# Altium schematic sheet FPGA-iCE-Cam.SchDoc
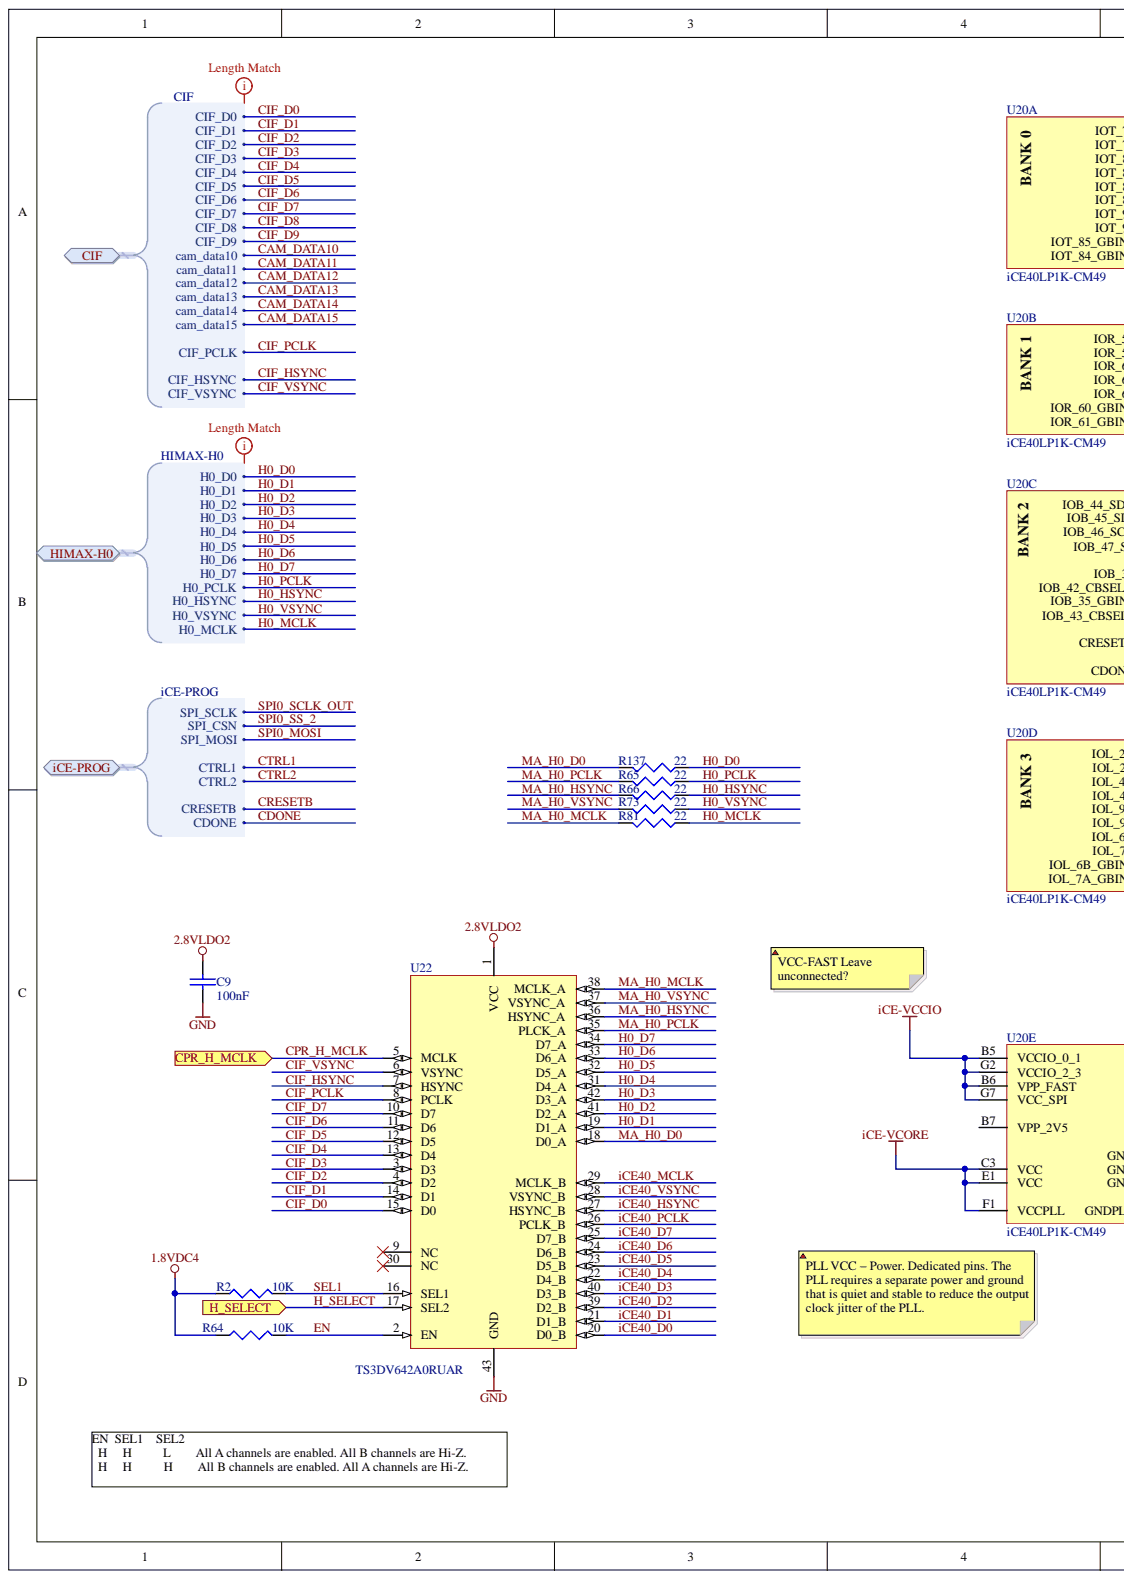
[diagram p0: FPGA-iCE-Cam.SchDoc, left]
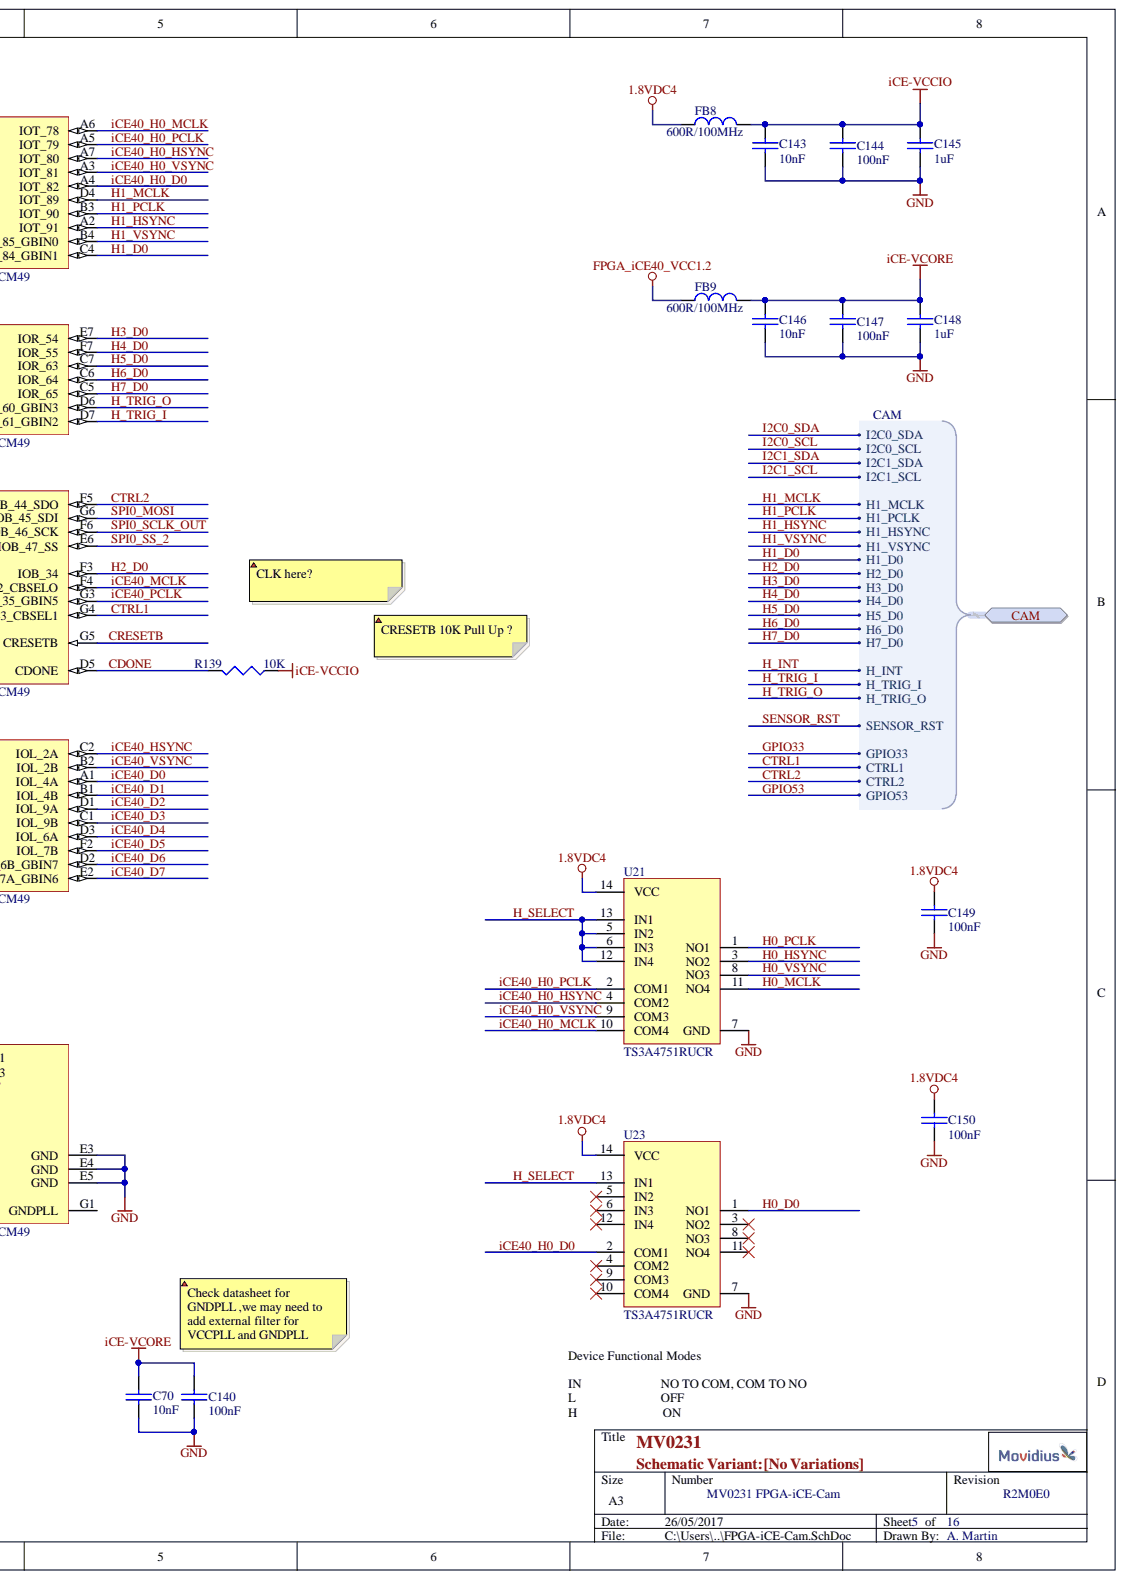
[diagram p1: FPGA-iCE-Cam.SchDoc, right]

## Parts
C9  100nF  [0402]
C70  10nF  [0201]
C140  100nF  [0402]
C143  10nF  [0201]
C144  100nF  [0402]
C145  1uF  [0402]
C146  10nF  [0201]
C147  100nF  [0402]
C148  1uF  [0402]
C149  100nF  [0402]
C150  100nF  [0402]
FB8  600R/100MHz  [0805]
FB9  600R/100MHz  [0805]
R2  10K  [0402]
R64  10K  [0402]
R65  22  [0402]
R66  22  [0402]
R73  22  [0402]
R81  22  [0402]
R137  22  [0402]
R139  10K  [0402]
U20  iCE40LP1K-CM49  [LATC-ucBGA-49]  (parts A,B,C,D,E)
U21  TS3A4751RUCR  [S-PX2QFN-14]
U22  TS3DV642A0RUAR  [PWQFN-N42]
U23  TS3A4751RUCR  [S-PX2QFN-14]

## Ports
CAM, CAM.CTRL1, CAM.CTRL2, CAM.GPIO33, CAM.GPIO53, CAM.H1_D0, CAM.H1_HSYNC, CAM.H1_MCLK, CAM.H1_PCLK, CAM.H1_VSYNC, CAM.H2_D0, CAM.H3_D0, CAM.H4_D0, CAM.H5_D0, CAM.H6_D0, CAM.H7_D0, CAM.H_INT, CAM.H_TRIG_I, CAM.H_TRIG_O, CAM.I2C0_SCL, CAM.I2C0_SDA, CAM.I2C1_SCL, CAM.I2C1_SDA, CAM.SENSOR_RST, CIF, CIF.cam_data10, CIF.cam_data11, CIF.cam_data12, CIF.cam_data13, CIF.cam_data14, CIF.cam_data15, CIF.CIF_D0, CIF.CIF_D1, CIF.CIF_D2, CIF.CIF_D3, CIF.CIF_D4, CIF.CIF_D5, CIF.CIF_D6, CIF.CIF_D7, CIF.CIF_D8, CIF.CIF_D9, CIF.CIF_HSYNC, CIF.CIF_PCLK, CIF.CIF_VSYNC, CPR_H_MCLK, H_SELECT, HIMAX-H0, HIMAX-H0.H0_D0, HIMAX-H0.H0_D1, HIMAX-H0.H0_D2, HIMAX-H0.H0_D3, HIMAX-H0.H0_D4, HIMAX-H0.H0_D5, HIMAX-H0.H0_D6, HIMAX-H0.H0_D7, HIMAX-H0.H0_HSYNC, HIMAX-H0.H0_MCLK, HIMAX-H0.H0_PCLK, HIMAX-H0.H0_VSYNC, iCE-PROG, iCE-PROG.CDONE, iCE-PROG.CRESETB, iCE-PROG.CTRL1, iCE-PROG.CTRL2, iCE-PROG.SPI_CSN, iCE-PROG.SPI_MOSI, iCE-PROG.SPI_SCLK

## Nets
1.8VDC4: C149.2 C150.2 FB8.2 R2.1 R64.1 U21.14(VCC) U23.14(VCC)
2.8VLDO2: C9.2 U22.1(VCC)
FPGA_iCE40_VCC1.2: FB9.2
GND: C9.1 C70.1 C140.1 C143.1 C144.1 C145.2 C146.1 C147.1 C148.2 C149.1 C150.1 U20.E3(GND) U20.E4(GND) U20.E5(GND) U21.7(GND) U22.43(GND) U23.7(GND)
iCE-VCCIO: C143.2 C144.2 C145.1 FB8.1 R139.1 U20.B5(VCCIO_0_1) U20.B6(VPP_FAST) U20.G2(VCCIO_2_3) U20.G7(VCC_SPI)
iCE-VCORE: C70.2 C140.2 C146.2 C147.2 C148.1 FB9.1 U20.C3(VCC) U20.E1(VCC) U20.F1(VCCPLL)
CDONE: R139.2 U20.D5(CDONE) ; port iCE-PROG
CIF_D0: U22.15(D0) ; port CIF
CIF_D1: U22.14(D1) ; port CIF
CIF_D2: U22.4(D2) ; port CIF
CIF_D3: U22.3(D3) ; port CIF
CIF_D4: U22.13(D4) ; port CIF
CIF_D5: U22.12(D5) ; port CIF
CIF_D6: U22.11(D6) ; port CIF
CIF_D7: U22.10(D7) ; port CIF
CIF_HSYNC: U22.7(HSYNC) ; port CIF
CIF_PCLK: U22.8(PCLK) ; port CIF
CIF_VSYNC: U22.6(VSYNC) ; port CIF
CPR_H_MCLK: U22.5(MCLK) ; port CPR_H_MCLK
CRESETB: U20.G5(CRESETB) ; port iCE-PROG
CTRL1: U20.G4(IOB_43_CBSEL1) ; port CAM, port iCE-PROG
CTRL2: U20.F5(IOB_44_SDO) ; port CAM, port iCE-PROG
EN: R64.2 U22.2(EN)
H0_D0: R137.1 U23.1(NO1) ; port HIMAX-H0
H0_D1: U22.19(D1_A) ; port HIMAX-H0
H0_D2: U22.41(D2_A) ; port HIMAX-H0
H0_D3: U22.42(D3_A) ; port HIMAX-H0
H0_D4: U22.31(D4_A) ; port HIMAX-H0
H0_D5: U22.32(D5_A) ; port HIMAX-H0
H0_D6: U22.33(D6_A) ; port HIMAX-H0
H0_D7: U22.34(D7_A) ; port HIMAX-H0
H0_HSYNC: R66.1 U21.3(NO2) ; port HIMAX-H0
H0_MCLK: R81.1 U21.11(NO4) ; port HIMAX-H0
H0_PCLK: R65.1 U21.1(NO1) ; port HIMAX-H0
H0_VSYNC: R73.1 U21.8(NO3) ; port HIMAX-H0
H1_D0: U20.C4(IOT_84_GBIN1) ; port CAM
H1_HSYNC: U20.A2(IOT_91) ; port CAM
H1_MCLK: U20.D4(IOT_89) ; port CAM
H1_PCLK: U20.B3(IOT_90) ; port CAM
H1_VSYNC: U20.B4(IOT_85_GBIN0) ; port CAM
H2_D0: U20.F3(IOB_34) ; port CAM
H3_D0: U20.E7(IOR_54) ; port CAM
H4_D0: U20.F7(IOR_55) ; port CAM
H5_D0: U20.C7(IOR_63) ; port CAM
H6_D0: U20.C6(IOR_64) ; port CAM
H7_D0: U20.C5(IOR_65) ; port CAM
H_SELECT: U21.5(IN2) U21.6(IN3) U21.12(IN4) U21.13(IN1) U22.17(SEL2) U23.13(IN1) ; port H_SELECT
H_TRIG_I: U20.D7(IOR_61_GBIN2) ; port CAM
H_TRIG_O: U20.D6(IOR_60_GBIN3) ; port CAM
iCE40_D0: U20.A1(IOL_4A) U22.20(D0_B)
iCE40_D1: U20.B1(IOL_4B) U22.21(D1_B)
iCE40_D2: U20.D1(IOL_9A) U22.39(D2_B)
iCE40_D3: U20.C1(IOL_9B) U22.40(D3_B)
iCE40_D4: U20.D3(IOL_6A) U22.22(D4_B)
iCE40_D5: U20.F2(IOL_7B) U22.23(D5_B)
iCE40_D6: U20.D2(IOL_6B_GBIN7) U22.24(D6_B)
iCE40_D7: U20.E2(IOL_7A_GBIN6) U22.25(D7_B)
iCE40_H0_D0: U20.A4(IOT_82) U23.2(COM1)
iCE40_H0_HSYNC: U20.A7(IOT_80) U21.4(COM2)
iCE40_H0_MCLK: U20.A6(IOT_78) U21.10(COM4)
iCE40_H0_PCLK: U20.A5(IOT_79) U21.2(COM1)
iCE40_H0_VSYNC: U20.A3(IOT_81) U21.9(COM3)
iCE40_HSYNC: U20.C2(IOL_2A) U22.27(HSYNC_B)
iCE40_MCLK: U20.F4(IOB_42_CBSELO) U22.29(MCLK_B)
iCE40_PCLK: U20.G3(IOB_35_GBIN5) U22.26(PCLK_B)
iCE40_VSYNC: U20.B2(IOL_2B) U22.28(VSYNC_B)
MA_H0_D0: R137.2 U22.18(D0_A)
MA_H0_HSYNC: R66.2 U22.36(HSYNC_A)
MA_H0_MCLK: R81.2 U22.38(MCLK_A)
MA_H0_PCLK: R65.2 U22.35(PLCK_A)
MA_H0_VSYNC: R73.2 U22.37(VSYNC_A)
SEL1: R2.2 U22.16(SEL1)
SPI0_MOSI: U20.G6(IOB_45_SDI) ; port iCE-PROG
SPI0_SCLK_OUT: U20.F6(IOB_46_SCK) ; port iCE-PROG
SPI0_SS_2: U20.E6(IOB_47_SS) ; port iCE-PROG
CAM_DATA10: ; port CIF
CAM_DATA11: ; port CIF
CAM_DATA12: ; port CIF
CAM_DATA13: ; port CIF
CAM_DATA14: ; port CIF
CAM_DATA15: ; port CIF
CIF_D8: ; port CIF
CIF_D9: ; port CIF
GPIO33: ; port CAM
GPIO53: ; port CAM
H_INT: ; port CAM
I2C0_SCL: ; port CAM
I2C0_SDA: ; port CAM
I2C1_SCL: ; port CAM
I2C1_SDA: ; port CAM
N00914:
N00915:
SENSOR_RST: ; port CAM

Not connected: U20 B7(VPP_2V5) G1(GNDPLL); U22 9(NC) 30(NC); U23 3(NO2) 4(COM2) 5(IN2) 6(IN3) 8(NO3) 9(COM3) 10(COM4) 11(NO4) 12(IN4)

## Library data of the parts
C9 C140 C144 C147 C149 C150 = CAP: Ceramic Capacitor SMD; DIST: Farnell; DIST PN: 1288282RL; ICCO PN: MV122005; MAN: C SMD 0402; MAN PN: 100nF SMD 0402; Component Kind: Standard
C70 C143 C146 = CAP: Ceramic Capacitor SMD; DIST: Farnell; DIST PN: 2524956RL; ICCO PN: MV122005; MAN: TDK; MAN PN: C0603X5R1A103K030BA; Component Kind: Standard
C145 C148 = CAP: Ceramic Capacitor SMD; DIST: Farnell; DIST PN: 1735523RL; ICCO PN: MV182024; MAN: MuRata; MAN PN: GRM155R61A105KE15; Component Kind: Standard
FB8 FB9 = INDUCTANCE1: inductance; DIST: Farnell; DIST PN: 1838838RL; ICCO PN: MV142024; MAN: FAIR-RITE; MAN PN: 2508056017Y2; Component Kind: Standard
R2 R64 R139 = RES1: Resistor SMD; ICCO PN: MV122008; MAN: R SMD 0603; MAN PN: 10K SMD 0603; Component Kind: Standard; Library Name: MvEoT_R1M0E0.SCHLIB
R65 R66 R73 R81 R137 = RES1: Resistor SMD; DIST: DIGIKEY; MAN: R SMD 0402; Component Kind: Standard; MAN PN: 22 SMD 0402
U20 = iCE40LP1K-CM49: iCE40 LP1K Series FPGA Family, 1280 Logic Cells, 1.2 V Core, 64 K Embedded RAM Bits, 49-ball ucBGA, Pb-Free, Tape and Reel; DIST: DIGIKEY; DIST PN: 220-1563-ND; Embedded RAM Bits: 64K; MAN: Lattice Semiconductor; Component Kind: Standard; Library Name: MvEoT_R1M0E0_1.SCHLIB; MAN PN: ICE40LP1K-CM49; Manufacturer: Lattice Semiconductor; Mounting Technology: Surface Mount; PackageDescription: 49-Ball Ultra Chip Ball Grid Array; PackageReference: ucBGA-49
U21 U23 = TS3A4751: 0.9-Ω Low-Voltage, Single-Supply, 4-Channel SPST Analog Switch; MAN: Texas Instruments; Component Kind: Standard; Library Name: MvEoT_R1M0E0_1.SCHLIB; MAN PN: TS3A4751RUCR
U22 = TS3DV642: 12-Channel 1:2 MUX/DEMUX with 1.8 V Compatible Control  and Power-Down Mode; MAN: Texas Instruments; Component Kind: Standard; Library Name: MvEoT_R1M0E0_1.SCHLIB; MAN PN: TS3DV642A0RUAR
